# Altium schematic sheet iF11L.SchDoc
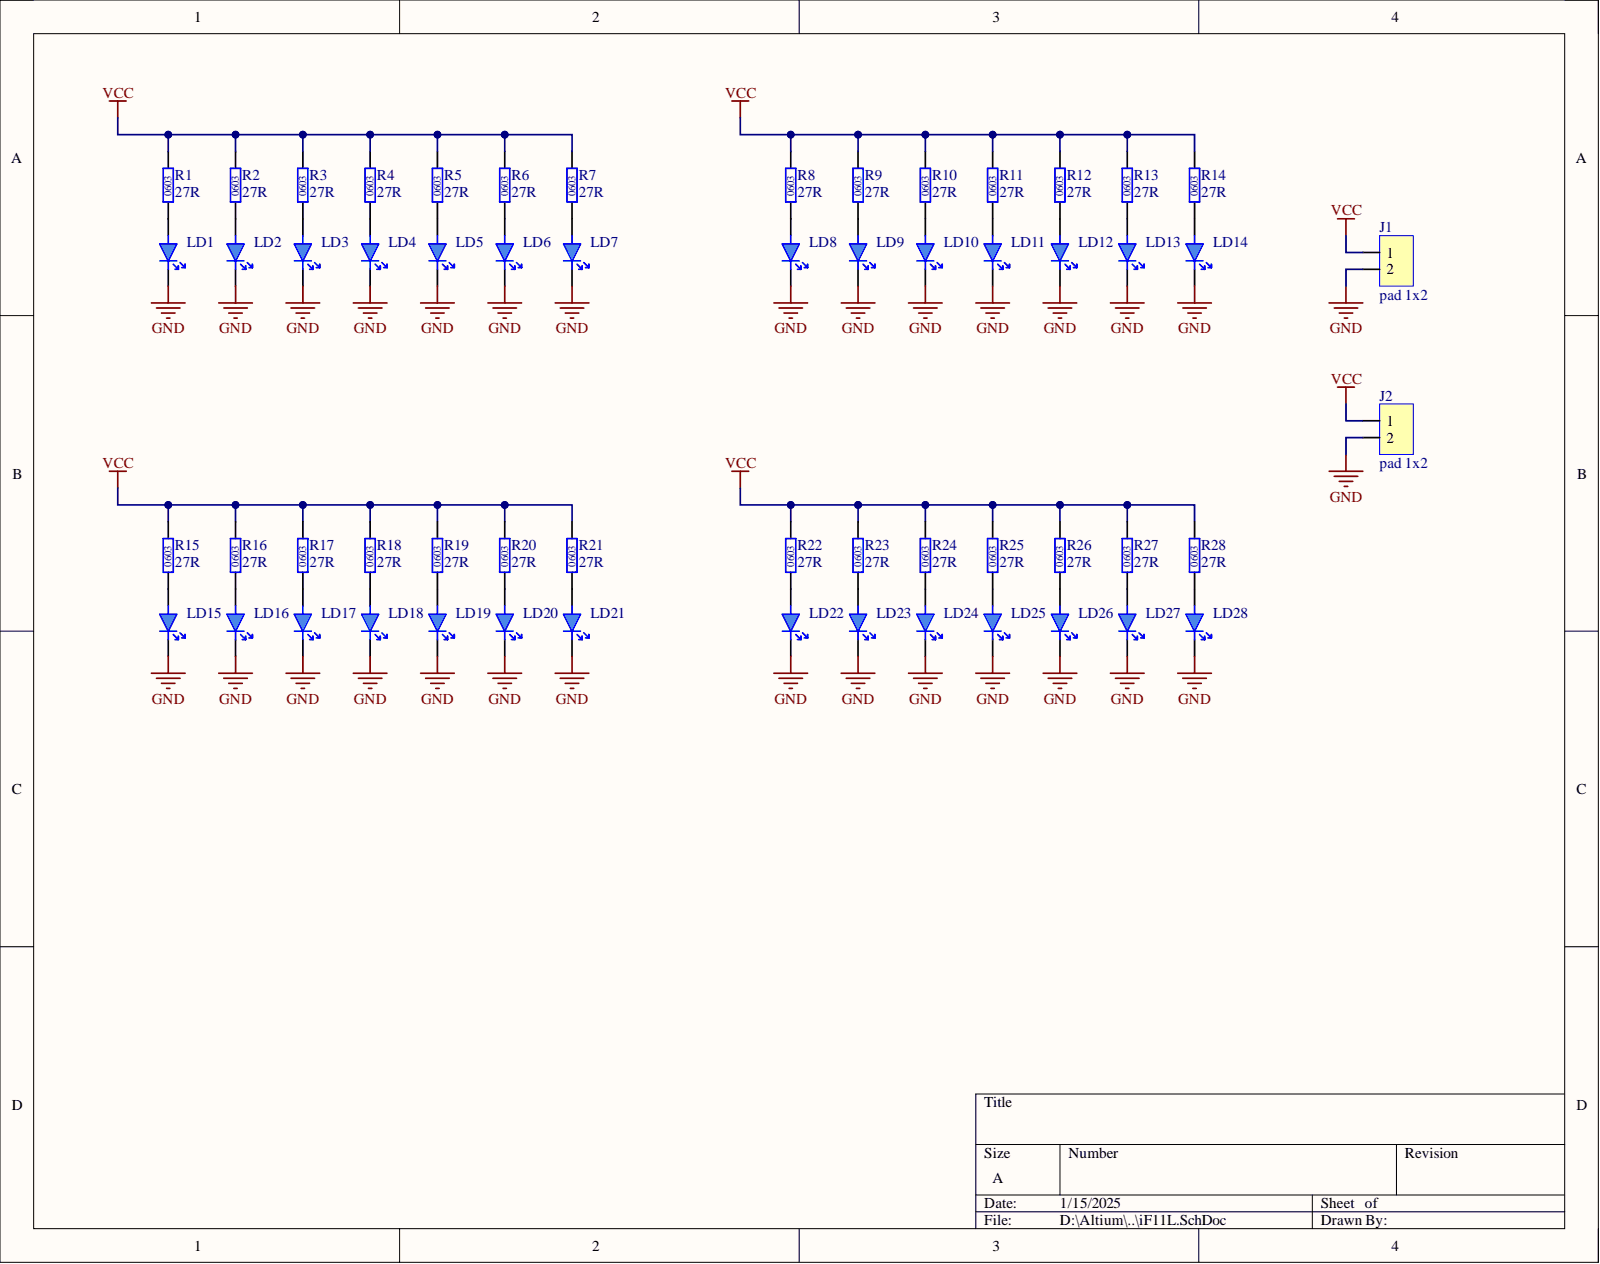
[diagram p0: iF11L.SchDoc]

## Parts
J1  pad 1x2  [Pad-1x2]
J2  pad 1x2  [Pad-1x2]
LD1  HQ19-2101UWCE2 0603  [LED-0603-WS]  (value not drawn)
LD2  HQ19-2101UWCE2 0603  [LED-0603-WS]  (value not drawn)
LD3  HQ19-2101UWCE2 0603  [LED-0603-WS]  (value not drawn)
LD4  HQ19-2101UWCE2 0603  [LED-0603-WS]  (value not drawn)
LD5  HQ19-2101UWCE2 0603  [LED-0603-WS]  (value not drawn)
LD6  HQ19-2101UWCE2 0603  [LED-0603-WS]  (value not drawn)
LD7  HQ19-2101UWCE2 0603  [LED-0603-WS]  (value not drawn)
LD8  HQ19-2101UWCE2 0603  [LED-0603-WS]  (value not drawn)
LD9  HQ19-2101UWCE2 0603  [LED-0603-WS]  (value not drawn)
LD10  HQ19-2101UWCE2 0603  [LED-0603-WS]  (value not drawn)
LD11  HQ19-2101UWCE2 0603  [LED-0603-WS]  (value not drawn)
LD12  HQ19-2101UWCE2 0603  [LED-0603-WS]  (value not drawn)
LD13  HQ19-2101UWCE2 0603  [LED-0603-WS]  (value not drawn)
LD14  HQ19-2101UWCE2 0603  [LED-0603-WS]  (value not drawn)
LD15  HQ19-2101UWCE2 0603  [LED-0603-WS]  (value not drawn)
LD16  HQ19-2101UWCE2 0603  [LED-0603-WS]  (value not drawn)
LD17  HQ19-2101UWCE2 0603  [LED-0603-WS]  (value not drawn)
LD18  HQ19-2101UWCE2 0603  [LED-0603-WS]  (value not drawn)
LD19  HQ19-2101UWCE2 0603  [LED-0603-WS]  (value not drawn)
LD20  HQ19-2101UWCE2 0603  [LED-0603-WS]  (value not drawn)
LD21  HQ19-2101UWCE2 0603  [LED-0603-WS]  (value not drawn)
LD22  HQ19-2101UWCE2 0603  [LED-0603-WS]  (value not drawn)
LD23  HQ19-2101UWCE2 0603  [LED-0603-WS]  (value not drawn)
LD24  HQ19-2101UWCE2 0603  [LED-0603-WS]  (value not drawn)
LD25  HQ19-2101UWCE2 0603  [LED-0603-WS]  (value not drawn)
LD26  HQ19-2101UWCE2 0603  [LED-0603-WS]  (value not drawn)
LD27  HQ19-2101UWCE2 0603  [LED-0603-WS]  (value not drawn)
LD28  HQ19-2101UWCE2 0603  [LED-0603-WS]  (value not drawn)
R1  27R  [R0603-LD]
R2  27R  [R0603-LD]
R3  27R  [R0603-LD]
R4  27R  [R0603-LD]
R5  27R  [R0603-LD]
R6  27R  [R0603-LD]
R7  27R  [R0603-LD]
R8  27R  [R0603-LD]
R9  27R  [R0603-LD]
R10  27R  [R0603-LD]
R11  27R  [R0603-LD]
R12  27R  [R0603-LD]
R13  27R  [R0603-LD]
R14  27R  [R0603-LD]
R15  27R  [R0603-LD]
R16  27R  [R0603-LD]
R17  27R  [R0603-LD]
R18  27R  [R0603-LD]
R19  27R  [R0603-LD]
R20  27R  [R0603-LD]
R21  27R  [R0603-LD]
R22  27R  [R0603-LD]
R23  27R  [R0603-LD]
R24  27R  [R0603-LD]
R25  27R  [R0603-LD]
R26  27R  [R0603-LD]
R27  27R  [R0603-LD]
R28  27R  [R0603-LD]

## Nets
GND: J1.2 J2.2 LD1.2 LD2.2 LD3.2 LD4.2 LD5.2 LD6.2 LD7.2 LD8.2 LD9.2 LD10.2 LD11.2 LD12.2 LD13.2 LD14.2 LD15.2 LD16.2 LD17.2 LD18.2 LD19.2 LD20.2 LD21.2 LD22.2 LD23.2 LD24.2 LD25.2 LD26.2 LD27.2 LD28.2
VCC: J1.1 J2.1 R1.2 R2.2 R3.2 R4.2 R5.2 R6.2 R7.2 R8.2 R9.2 R10.2 R11.2 R12.2 R13.2 R14.2 R15.2 R16.2 R17.2 R18.2 R19.2 R20.2 R21.2 R22.2 R23.2 R24.2 R25.2 R26.2 R27.2 R28.2
NetLD1_1: LD1.1 R1.1
NetLD2_1: LD2.1 R2.1
NetLD3_1: LD3.1 R3.1
NetLD4_1: LD4.1 R4.1
NetLD5_1: LD5.1 R5.1
NetLD6_1: LD6.1 R6.1
NetLD7_1: LD7.1 R7.1
NetLD8_1: LD8.1 R8.1
NetLD9_1: LD9.1 R9.1
NetLD10_1: LD10.1 R10.1
NetLD11_1: LD11.1 R11.1
NetLD12_1: LD12.1 R12.1
NetLD13_1: LD13.1 R13.1
NetLD14_1: LD14.1 R14.1
NetLD15_1: LD15.1 R15.1
NetLD16_1: LD16.1 R16.1
NetLD17_1: LD17.1 R17.1
NetLD18_1: LD18.1 R18.1
NetLD19_1: LD19.1 R19.1
NetLD20_1: LD20.1 R20.1
NetLD21_1: LD21.1 R21.1
NetLD22_1: LD22.1 R22.1
NetLD23_1: LD23.1 R23.1
NetLD24_1: LD24.1 R24.1
NetLD25_1: LD25.1 R25.1
NetLD26_1: LD26.1 R26.1
NetLD27_1: LD27.1 R27.1
NetLD28_1: LD28.1 R28.1

## Library data of the parts
J1 J2 = Pad-1x2: 2 Pin SMD Pad; Component Kind: Standard; Library Name: Connector.IntLib
LD1-LD28 = LED WS: Component Kind: Standard; Library Name: Optoelectronics.IntLib; Supplier: 广州市亿毫安电子有限公司
R1-R28 = R 0603 27R: 5%; Manufacturer: LAGEO; Part No: W-T-RE-RS03K270JT; Material Name: 贴片电阻0603 27Ω 5%; Product Code: WP0002702; Component Kind: Standard; Supplier: 南京南山半导体有限公司; Library Name: Rescap.IntLib
